# Altium schematic sheet STLink_V2.SchDoc(STLink_V2)
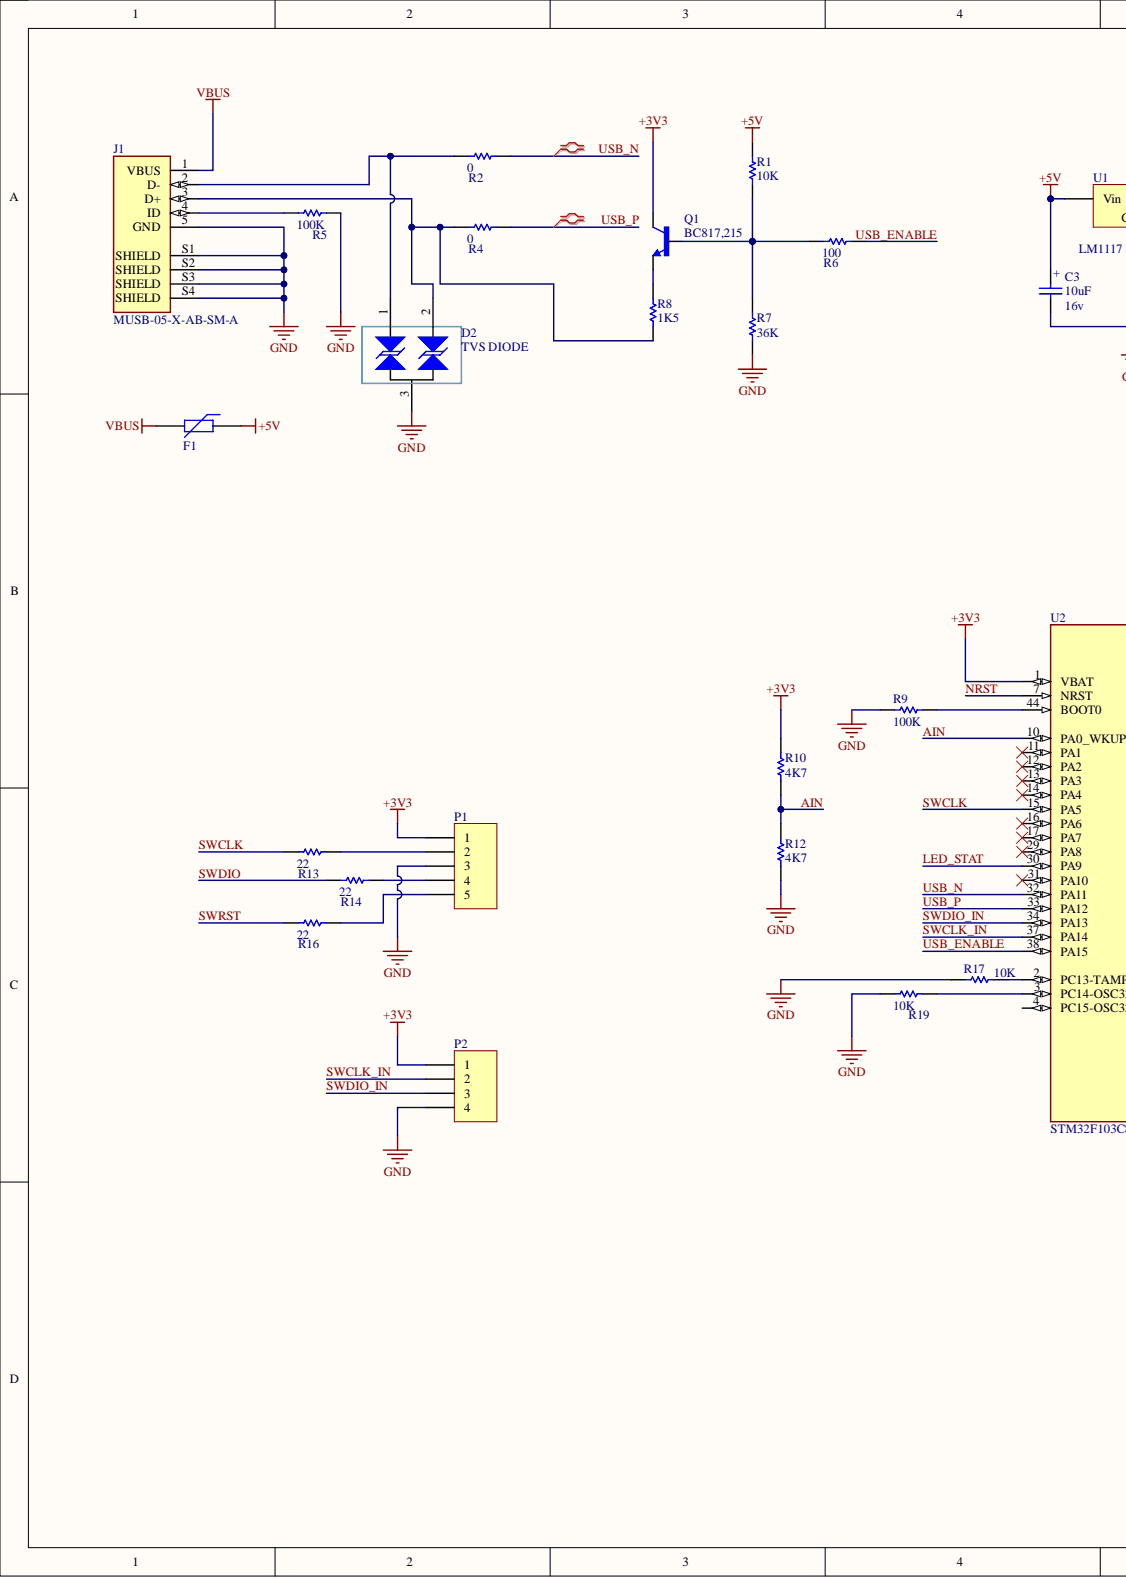
[diagram p0: STLink_V2.SchDoc, left]
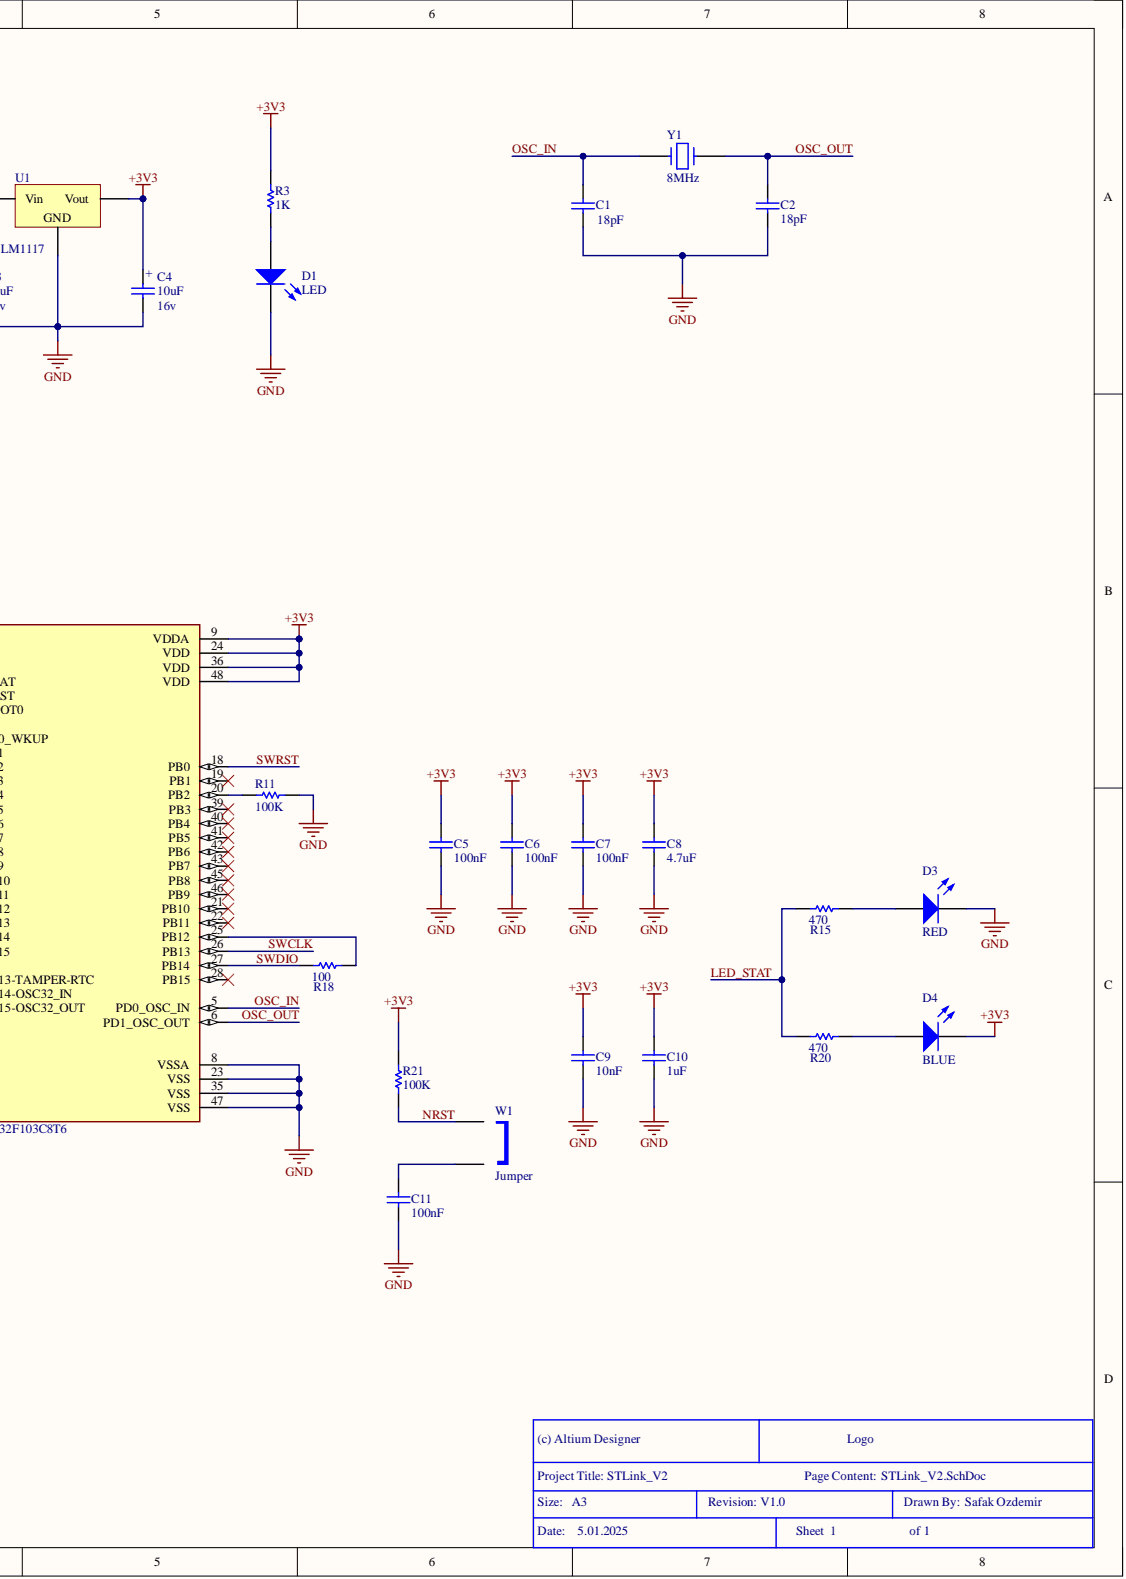
[diagram p1: STLink_V2.SchDoc, right]

## Parts
C1  18pF  [C805]
C2  18pF  [C805]
C3  10uF  Volte: 16v  [MY_SMC_B]
C4  10uF  Volte: 16v  [MY_SMC_B]
C5  100nF  [C805]
C6  100nF  [C805]
C7  100nF  [C805]
C8  4.7uF  [C805]
C9  10nF  [C805]
C10  1uF  [C805]
C11  100nF  [C805]
D1  LED  [MY_LED_1206]
D2  TVS DIODE  [SOT-23]
D3  RED  [MY_LED_0805]
D4  BLUE  [MY_LED_0805]
F1  (no value)  [PTC-0805]
J1  MUSB-05-X-AB-SM-A  [SAMTEC_MUSB-05-X-AB-SM-A]
P1  Header 5  [My_1X05]  (value not drawn)
P2  Header 4  [My_1X04]  (value not drawn)
Q1  BC817,215  [SOT95P230X110-3N]
R1  10K  [MY_0805]
R2  0  [MY_0805]
R3  1K  [MY_0805]
R4  0  [MY_0805]
R5  100K  [MY_0805]
R6  100  [MY_0805]
R7  36K  [MY_0805]
R8  1K5  [MY_0805]
R9  100K  [MY_0805]
R10  4K7  [MY_0805]
R11  100K  [MY_0805]
R12  4K7  [MY_0805]
R13  22  [MY_0805]
R14  22  [MY_0805]
R15  470  [MY_0805]
R16  22  [MY_0805]
R17  10K  [MY_0805]
R18  100  [MY_0805]
R19  10K  [MY_0805]
R20  470  [MY_0805]
R21  100K  [MY_0805]
U1  LM1117  [MY_SOT223]
U2  STM32F103C8T6  [QFP50P900X900X160-48N]
W1  Jumper  [My_1X02]
Y1  8MHz  [My_Crystal_SMD]

## Nets
+3V3: C4.+ C5.2 C6.2 C7.2 C8.2 C9.2 C10.2 D4.K P1.1 P2.1 Q1.3 R3.2 R10.2 R21.2 U1.2 U2.1(VBAT) U2.9(VDDA) U2.24(VDD) U2.36(VDD) U2.48(VDD)
+5V: C3.+ F1.1 R1.2 U1.3
GND: C1.1 C2.1 C3.- C4.- C5.1 C6.1 C7.1 C8.1 C9.1 C10.1 C11.1 D1.K D2.3 D3.K J1.5(GND) J1.S1(SHIELD) J1.S2(SHIELD) J1.S3(SHIELD) J1.S4(SHIELD) P1.3 P2.4 R5.2 R7.2 R9.1 R11.2 R12.1 R17.1 R19.1 U1.1 U2.8(VSSA) U2.23(VSS) U2.35(VSS) U2.47(VSS)
VBUS: F1.2 J1.1(VBUS)
AIN: R10.1 R12.2 U2.10(PA0_WKUP)
LED_STAT: R15.1 R20.1 U2.30(PA9)
NRST: R21.1 U2.7(NRST) W1.1
OSC_IN: C1.2 U2.5(PD0_OSC_IN) Y1.1
OSC_OUT: C2.2 U2.6(PD1_OSC_OUT) Y1.2
SWCLK: R13.1 U2.15(PA5) U2.26(PB13)
SWCLK_IN: P2.2 U2.37(PA14)
SWDIO: R14.1 R18.1 U2.27(PB14)
SWDIO_IN: P2.3 U2.34(PA13)
SWRST: R16.1 U2.18(PB0)
USB_ENABLE: R6.2 U2.38(PA15)
USB_N: R2.2 U2.32(PA11)
USB_P: R4.2 U2.33(PA12)
NetC11_2: C11.2 W1.2
NetD1_A: D1.A R3.1
NetD2_1: D2.1 J1.2(D-) R2.1
NetD2_2: D2.2 J1.3(D+) R4.1 R8.1
NetD3_A: D3.A R15.2
NetD4_A: D4.A R20.2
NetJ1_4: J1.4(ID) R5.1
NetP1_2: P1.2 R13.2
NetP1_4: P1.4 R14.2
NetP1_5: P1.5 R16.2
NetQ1_1: Q1.1 R1.1 R6.1 R7.1
NetQ1_2: Q1.2 R8.2
NetR9_2: R9.2 U2.44(BOOT0)
NetR11_1: R11.1 U2.20(PB2)
NetR17_2: R17.2 U2.2(PC13-TAMPER-RTC)
NetR18_2: R18.2 U2.25(PB12)
NetR19_2: R19.2 U2.3(PC14-OSC32_IN)

Not connected: U2 4(PC15-OSC32_OUT) 11(PA1) 12(PA2) 13(PA3) 14(PA4) 16(PA6) 17(PA7) 19(PB1) 21(PB10) 22(PB11) 28(PB15) 29(PA8) 31(PA10) 39(PB3) 40(PB4) 41(PB5) 42(PB6) 43(PB7) 45(PB8) 46(PB9)

## Library data of the parts
C1 C2 C5-C11 = Cap: Capacitor; Designer: Parsa Malekpour; Component Kind: Standard; Library Name: STLink_V2.SchLib
  C1 C2: Value: 18pF
  C5-C7 C11: Value: 100nF
  C8: Value: 4.7uF
  C9: Value: 10nF
  C10: Value: 1uF
C3 C4 = Cap Pol: Polar capacitor; ASiD Part Number: C-AS; Component Kind: Standard; Library Name: Mylib.IntLib; Value: 10uF
D1 D3 D4 = LED: Typical INFRARED GaAs LED; ASiD Part Number: LED-AS; Component Kind: Standard; Library Name: Mylib.IntLib
D2 = SM712: Component Kind: Standard; Library Name: STLink_V2.SchLib
F1 = PTC: Component Kind: Standard; Description: PTC; Library Name: STLink_V2.SchLib; PackageReference: 6-0805; PackageVersion: Mar-2003; Published: 24-Mar-1999
J1 = MUSB-05-X-AB-SM-A: USB - mini AB Receptacle Connector 5 Position Surface Mount, Right Angle; MF: Samtec; MP: MUSB-05-S-AB-SM-A; Availability: In Stock; Check_prices: https://www.snapeda.com/parts/MUSB-05-S-AB-SM-A/Samtec/view-part/345697/?ref=eda; Component Kind: Standard; Library Name: STLink_V2.SchLib; Package: None; Price: None; Purchase-URL: https://pricing.snapeda.com/search/part/MUSB-05-S-AB-SM-A/?ref=eda; SnapEDA_Link: https://www.snapeda.com/parts/MUSB-05-S-AB-SM-A/Samtec/view-part/345697/?ref=snap
P1 = Header 5: Header, 5-Pin; ASiD Part Number: Header 5-AS; Component Kind: Standard; Library Name: Mylib.IntLib; Signal Integrity: Connector
P2 = Header 4: Header, 4-Pin; ASiD Part Number: Header 4-AS; Component Kind: Standard; Library Name: Mylib.IntLib; Signal Integrity: Connector
Q1 = BC817,215: Bipolar (BJT) Transistor NPN 45 V 500 mA 100MHz 250 mW Surface Mount TO-236AB; MF: Nexperia USA Inc.; Availability: In Stock; Component Kind: Standard; Library Name: STLink_V2.SchLib; MP: BC817,215; Package: TO-236-3 Nexperia USA Inc.; Price: None; Purchase-URL: https://pricing.snapeda.com/search/part/BC817,215/?ref=eda
R1-R21 = Res: Standard resistor; ASiD Part Number: Res-AS; Component Kind: Standard; Library Name: Mylib.IntLib
  R1 R17 R19: Value: 10K
  R2 R4: Value: 0
  R3: Value: 1K
  R5 R9 R11 R21: Value: 100K
  R6 R18: Value: 100
  R7: Value: 36K
  R8: Value: 1K5
  R10 R12: Value: 4K7
  R13 R14 R16: Value: 22
  R15 R20: Value: 470
U1 = LM1117: Low Drop Voltage Reg; ASiD Part Number: LM1117-AS; Component Kind: Standard; Library Name: Mylib.IntLib
U2 = STM32F103C8T6: ARM® Cortex®-M3 STM32F1 Microcontroller IC 32-Bit 72MHz 64KB (64K x 8) FLASH 48-LQFP (7x7); MF: STMicroelectronics; Check_prices: https://www.snapeda.com/parts/STM32F103C8T6/STMicroelectronics/view-part/?ref=eda; Component Kind: Standard; Library Name: STLink_V2.SchLib; MP: STM32F103C8T6; Package: LQFP-48 STMicroelectronics; SnapEDA_Link: https://www.snapeda.com/parts/STM32F103C8T6/STMicroelectronics/view-part/?ref=snap
W1 = Jumper: Jumper Wire; ASiD Part Number: Jumper-AS; Component Kind: Standard; Library Name: Mylib.IntLib
Y1 = CRYSTAL: ASiD Part Number: Crystal-AS; Component Kind: Standard; Description: Crystal; Library Name: Mylib.IntLib
